# Altium schematic sheet Connections.SchDoc
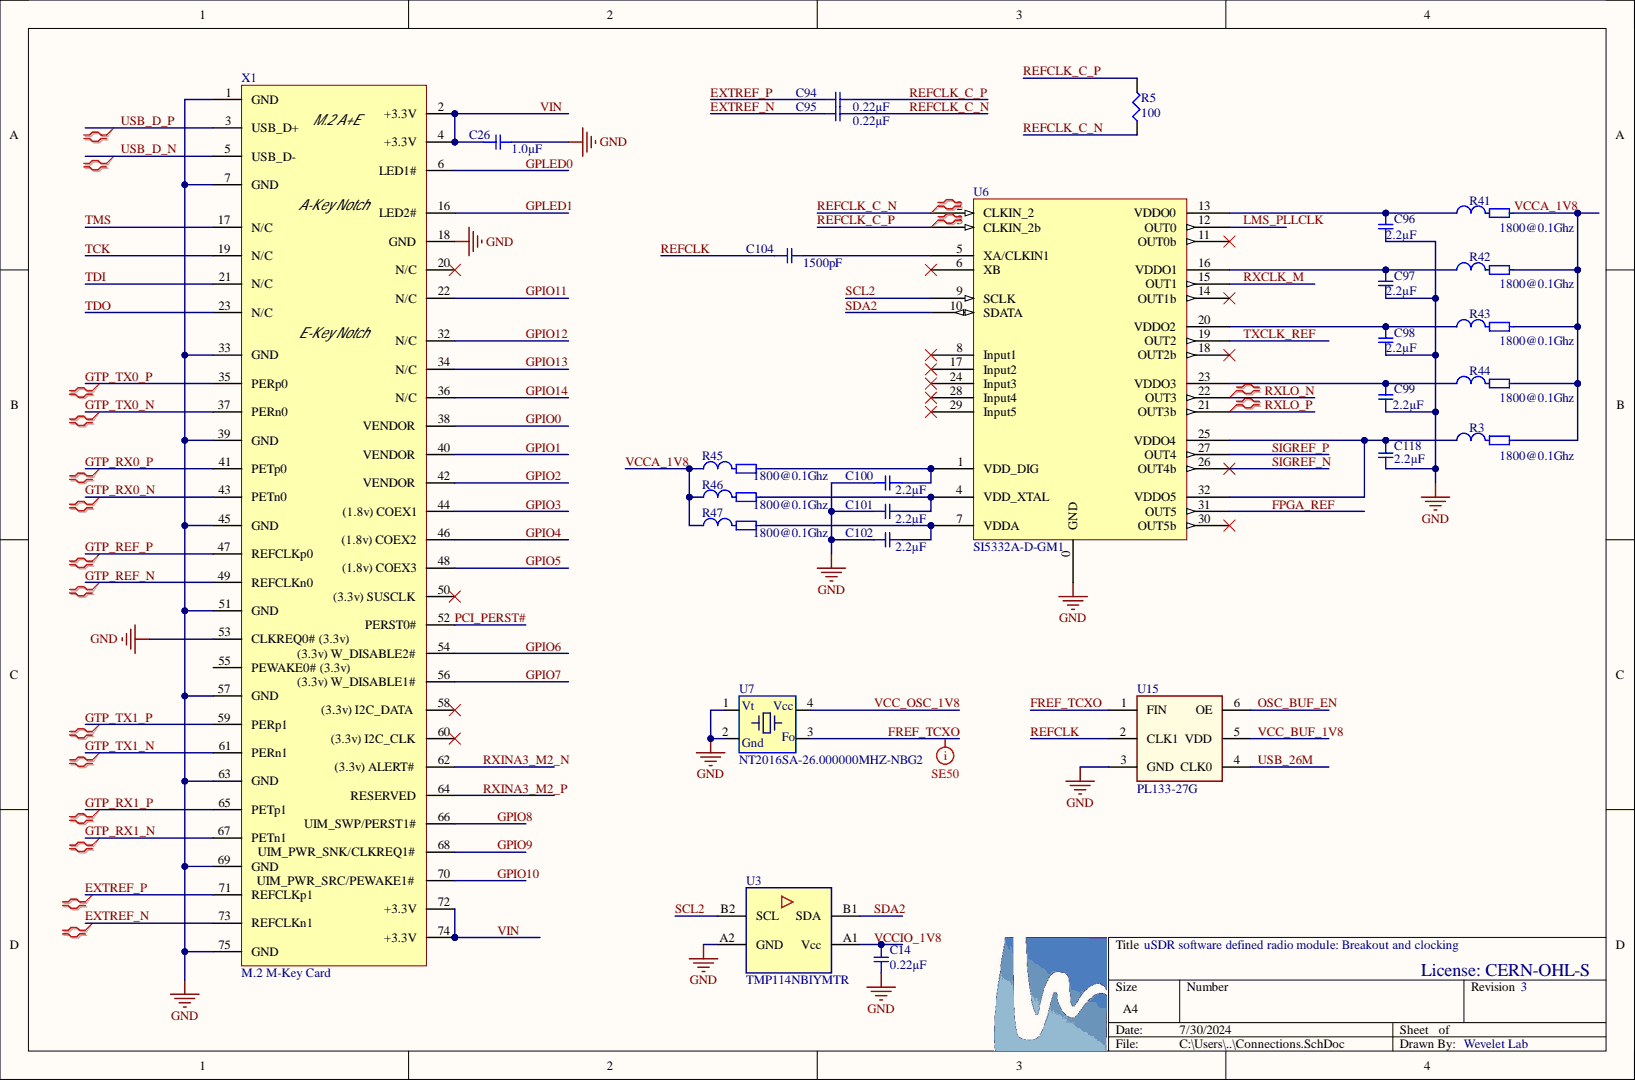
[diagram p0: Connections.SchDoc]

## Parts
C14  value 0.22µF  [SMD-0201C]  (hidden comment: GRM033C80J224ME90D)
C26  value 1.0µF  [SMD-0201C]  (hidden comment: GRM033D70J105ME01D)
C94  value 0.22µF  [SMD-0201C]  (hidden comment: GRM033C80J224ME90D)
C95  value 0.22µF  [SMD-0201C]  (hidden comment: GRM033C80J224ME90D)
C96  value 2.2µF  [SMD-0201C]  (hidden comment: GRM033C80G225ME01D)
C97  value 2.2µF  [SMD-0201C]  (hidden comment: GRM033C80G225ME01D)
C98  value 2.2µF  [SMD-0201C]  (hidden comment: GRM033C80G225ME01D)
C99  value 2.2µF  [SMD-0201C]  (hidden comment: GRM033C80G225ME01D)
C100  value 2.2µF  [SMD-0201C]  (hidden comment: GRM033C80G225ME01D)
C101  value 2.2µF  [SMD-0201C]  (hidden comment: GRM033C80G225ME01D)
C102  value 2.2µF  [SMD-0201C]  (hidden comment: GRM033C80G225ME01D)
C104  value 1500pF  [SMD-0201C]  (hidden comment: GRM033R71E152KA01D)
C118  value 2.2µF  [SMD-0201C]  (hidden comment: GRM033C80G225ME01D)
R3  value 1800@0.1Ghz  [SMD-0201-RES]  (hidden comment: BLM03HD182FN1)
R5  100  [SMD-0201-RES]
R41  value 1800@0.1Ghz  [SMD-0201-RES]  (hidden comment: BLM03HD182FN1)
R42  value 1800@0.1Ghz  [SMD-0201-RES]  (hidden comment: BLM03HD182FN1)
R43  value 1800@0.1Ghz  [SMD-0201-RES]  (hidden comment: BLM03HD182FN1)
R44  value 1800@0.1Ghz  [SMD-0201-RES]  (hidden comment: BLM03HD182FN1)
R45  value 1800@0.1Ghz  [SMD-0201-RES]  (hidden comment: BLM03HD182FN1)
R46  value 1800@0.1Ghz  [SMD-0201-RES]  (hidden comment: BLM03HD182FN1)
R47  value 1800@0.1Ghz  [SMD-0201-RES]  (hidden comment: BLM03HD182FN1)
U3  TMP114NBIYMTR  [BGA WCSP4]
U6  SI5332A-D-GM1  [QFN-32(5X5/0.5)SI]
U7  NT2016SA-26.000000MHZ-NBG2  [TCXO1612_2016_COMBINED]
U15  PL133-27G  [TDFN-6 -2013]
X1  M.2 M-Key Card  [Conn Edge M.2 A/E Key]  (no BOM)

## Nets
GND: C14.2 C26.2 C96.1 C97.1 C98.1 C99.1 C100.2 C101.2 C102.2 C118.2 U3.A2(GND) U6.0(GND) U7.1(Vt) U7.2 U15.3(GND) X1.1(GND) X1.7(GND) X1.18(GND) X1.33(GND) X1.39(GND) X1.45(GND) X1.51(GND) X1.53(CLKREQ0#_(3.3v)) X1.57(GND) X1.63(GND) X1.69(GND) X1.75(GND)
EXTREF_N: C95.2 X1.73(REFCLKn1)
EXTREF_P: C94.2 X1.71(REFCLKp1)
FPGA_REF: U6.31(OUT5)
FREF_TCXO: U7.3(Fo) U15.1(FIN)
GPIO0: X1.38(VENDOR)
GPIO1: X1.40(VENDOR)
GPIO2: X1.42(VENDOR)
GPIO3: X1.44((1.8v)_COEX1)
GPIO4: X1.46((1.8v)_COEX2)
GPIO5: X1.48((1.8v)_COEX3)
GPIO6: X1.54((3.3v)_W_DISABLE2#)
GPIO7: X1.56((3.3v)_W_DISABLE1#)
GPIO8: X1.66(UIM_SWP/PERST1#)
GPIO9: X1.68(UIM_PWR_SNK/CLKREQ1#)
GPIO10: X1.70(UIM_PWR_SRC/PEWAKE1#)
GPIO11: X1.22(N/C)
GPIO12: X1.32(N/C)
GPIO13: X1.34(N/C)
GPIO14: X1.36(N/C)
GPLED0: X1.6(LED1#)
GPLED1: X1.16(LED2#)
GTP_REF_N: X1.49(REFCLKn0)
GTP_REF_P: X1.47(REFCLKp0)
GTP_RX0_N: X1.43(PETn0)
GTP_RX0_P: X1.41(PETp0)
GTP_RX1_N: X1.67(PETn1)
GTP_RX1_P: X1.65(PETp1)
GTP_TX0_N: X1.37(PERn0)
GTP_TX0_P: X1.35(PERp0)
GTP_TX1_N: X1.61(PERn1)
GTP_TX1_P: X1.59(PERp1)
LMS_PLLCLK: U6.12(OUT0)
OSC_BUF_EN: U15.6(OE)
PCI_PERST#: X1.52(PERST0#)
REFCLK: C104.1 U15.2(CLK1)
REFCLK_C_N: C95.1 R5.1 U6.2(CLKIN_2)
REFCLK_C_P: C94.1 R5.2 U6.3(CLKIN_2b)
RXCLK_M: U6.15(OUT1)
RXINA3_M2_N: X1.62((3.3v)_ALERT#)
RXINA3_M2_P: X1.64(RESERVED)
RXLO_N: U6.22(OUT3)
RXLO_P: U6.21(OUT3b)
SCL2: U3.B2(SCL) U6.9(SCLK)
SDA2: U3.B1(SDA) U6.10(SDATA)
SIGREF_N: U6.26(OUT4b)
SIGREF_P: U6.27(OUT4)
TCK: X1.19(N/C)
TDI: X1.21(N/C)
TDO: X1.23(N/C)
TMS: X1.17(N/C)
TXCLK_REF: U6.19(OUT2)
USB_26M: U15.4(CLK0)
USB_D_N: X1.5(USB_D-)
USB_D_P: X1.3(USB_D+)
VCC_BUF_1V8: U15.5(VDD)
VCC_OSC_1V8: U7.4(Vcc)
VCCA_1V8: R3.2 R41.2 R42.2 R43.2 R44.2 R45.1 R46.1 R47.1
VCCIO_1V8: C14.1 U3.A1(Vcc)
VIN: C26.1 X1.2(+3.3V) X1.4(+3.3V) X1.72(+3.3V) X1.74(+3.3V)
NetC96_2: C96.2 R41.1 U6.13(VDDO0)
NetC97_2: C97.2 R42.1 U6.16(VDDO1)
NetC98_2: C98.2 R43.1 U6.20(VDDO2)
NetC99_2: C99.2 R44.1 U6.23(VDDO3)
NetC100_1: C100.1 R45.2 U6.1(VDD_DIG)
NetC101_1: C101.1 R46.2 U6.4(VDD_XTAL)
NetC102_1: C102.1 R47.2 U6.7(VDDA)
NetC104_2: C104.2 U6.5(XA/CLKIN1)
NetC118_1: C118.1 R3.1 U6.25(VDDO4) U6.32(VDDO5)

Not connected: U6 6(XB) 8(Input1) 11(OUT0b) 14(OUT1b) 17(Input2) 18(OUT2b) 24(Input3) 28(Input4) 29(Input5) 30(OUT5b); X1 20(N/C) 50((3.3v)_SUSCLK) 55(PEWAKE0#_(3.3v)) 58((3.3v)_I2C_DATA) 60((3.3v)_I2C_CLK)

## Library data of the parts
C14 C94 C95 = GRM033C80J224ME90D: CAP CER 0.22UF 6.3V X6S 0201; Manufacturer: Murata Electronics North America; @ qty: 0; Minimum Quantity: 1; Tolerance: ±20%; Unit Price (USD): 0.1; Applications: General Purpose; Component Kind: Standard; Datasheets: http://www.murata.com/~/media/webrenewal/support/library/catalog/products/capacitor/mlcc/c02e.pdf; Digi-Key Part Number: 490-9982-1-ND; Factory Stock: 0; Failure Rate: Surface Mount, MLCC; Features: -; Height - Seated (Max): 0.013" (0.33mm); Image: //media.digikey.com/Renders/Murata%20Renders/ZRB-SERIES-0402.jpg; Lead Spacing: -; Mounting Type: 0201 (0603 Metric); Operating Temperature: -55°C ~ 105°C; Package / Case: 0.024" L x 0.012" W (0.60mm x 0.30mm); Packaging: Cut Tape (CT); Part Number: GRM033C80J224ME90D; Part Status: Active; Quantity Available: 381529; Ratings: -; Series: GRM; Size / Dimension: -; Temperature Coefficient: X6S; Thickness (Max): -; Voltage: 6.3V; Library Name: USDR.IntLib
C26 = GRM033D70J105ME01D: CAP CER 1.0UF 6.3V X7T 0201; Manufacturer: Murata Electronics North America; @ qty: 0; Minimum Quantity: 1; Tolerance: ±20%; Unit Price (USD): 0.54; Applications: General Purpose; Component Kind: Standard; Datasheets: http://psearch.en.murata.com/capacitor/product/GRM035R60J475ME15%23.pdf; Digi-Key Part Number: 490-GRM033D70J105ME01DTR-ND; Factory Stock: 0; Failure Rate: Surface Mount, MLCC; Features: Derating Recommended; Height - Seated (Max): 0.022" (0.55mm); Image: //media.digikey.com/Renders/Murata%20Renders/ZRB-SERIES-0402.jpg; Lead Spacing: -; Mounting Type: 0201 (0603 Metric); Operating Temperature: -55°C ~125°C; Package / Case: 0.024" L x 0.012" W (0.60mm x 0.30mm); Packaging: Cut Tape (CT); Part Number: GRM033D70J105ME01D; Part Status: Active; Quantity Available: 67188; Ratings: -; Series: GRM; Size / Dimension: -; Temperature Coefficient: X7T; Thickness (Max): -; Voltage: 6.3V; Library Name: USDR.IntLib
C96-C102 C118 = GRM033C80G225ME01D: CAP CER 2.2UF 4V X6S 0201; Manufacturer: Murata Electronics North America; @ qty: 0; Minimum Quantity: 1; Tolerance: ±20%; Unit Price (USD): 0.54; Voltage: 6.3V; Applications: General Purpose; Component Kind: Standard; Datasheets: http://psearch.en.murata.com/capacitor/product/GRM035R60J475ME15%23.pdf; Digi-Key Part Number: 490-GRM033C80G225ME01DTR-ND; Factory Stock: 0; Failure Rate: Surface Mount, MLCC; Features: Derating Recommended; Height - Seated (Max): 0.022" (0.55mm); Image: //media.digikey.com/Renders/Murata%20Renders/ZRB-SERIES-0402.jpg; Lead Spacing: -; Mounting Type: 0201 (0603 Metric); Operating Temperature: -55°C ~105°C; Package / Case: 0.024" L x 0.012" W (0.60mm x 0.30mm); Packaging: Cut Tape (CT); Part Number: GRM033C80G225ME01D; Part Status: Active; Quantity Available: 67188; Ratings: -; Series: GRM; Size / Dimension: -; Temperature Coefficient: X6S; Thickness (Max): -; Library Name: USDR.IntLib
C104 = GRM033R71E152KA01D: CAP CER 1500PF 25V X7R 0201; Manufacturer: Murata Electronics North America; @ qty: 0; Minimum Quantity: 1; Tolerance: ±10%; Unit Price (USD): 0.1; Applications: General Purpose; Component Kind: Standard; Datasheets: http://www.murata.com/~/media/webrenewal/support/library/catalog/products/capacitor/mlcc/c02e.pdf; Digi-Key Part Number: 490-3185-1-ND; Factory Stock: 0; Failure Rate: Surface Mount, MLCC; Features: -; Height - Seated (Max): 0.013" (0.33mm); Image: //media.digikey.com/Renders/Murata%20Renders/ZRB-SERIES-0402.jpg; Lead Spacing: -; Mounting Type: 0201 (0603 Metric); Operating Temperature: -55°C ~ 125°C; Package / Case: 0.024" L x 0.012" W (0.60mm x 0.30mm); Packaging: Cut Tape (CT); Part Number: GRM033R71E152KA01D; Part Status: Active; Quantity Available: 60053; Ratings: -; Series: GRM; Size / Dimension: -; Temperature Coefficient: X7R; Thickness (Max): -; Voltage: 25V; Library Name: USDR.IntLib
R3 R41-R47 = BLM03HD182FN1: BEAD 3.8Ohm/100mA 1800@0.1Ghz/3000@1.0Ghz; Manufacturer: TDKMurata Electronics North America; Tolerance: ±3%; Component Kind: Standard; Link: https://product.tdk.com/system/files/dam/doc/product/inductor/inductor/smd/catalog/inductor_commercial_high-frequency_mlg0603p_en.pdf; Name: BLM03HD182FN1; Library Name: USDR.IntLib
R5 = ERJ-1GEJ101C: RES SMD 100 OHM 5% 1/20W 0201; Manufacturer: Panasonic Electronic Components; @ qty: 1500; Factory Stock: 0; Minimum Quantity: 1; Power (Watts): 0.05W, 1/20W; Temperature Coefficient: ±200ppm/°C; Unit Price (USD): 0.00787; Component Kind: Standard; Composition: Thick Film; Datasheets: //media.digikey.com/pdf/Data%20Sheets/Panasonic%20Resistors%20Thermistors%20PDFs/ERJ%205%25.pdf; Digi-Key Part Number: P100AGCT-ND; Failure Rate: -; Features: -; Height - Seated (Max): 0.010" (0.26mm); Image: //media.digikey.com/Renders/Panasonic%20Renders/ERJ-0201%20Pkg.jpg; Number of Terminations: 2; Operating Temperature: -55°C ~ 125°C; Package / Case: 0201 (0603 Metric); Packaging: Cut Tape (CT); Part Number: ERJ-1GEJ101C; Part Status: Active; Quantity Available: 115724; Series: ERJ; Size / Dimension: 0.024" L x 0.012" W (0.60mm x 0.30mm); Supplier Device Package: 0201; Tolerance: ±5%; Value: 100; Library Name: USDR.IntLib
U3 = TMP114NBIYMTR: IC Thermosensor; Manufacturer: TI; Misc: IC temperature sensor I2C, DSBGA-6; Component Kind: Standard; Link: https://octopart.com/tmp108aiyffr-texas+instruments-28946339; Name: TMP114NBIYMTR; Library Name: USDR.IntLib
U6 = SI5332A-D-GM1: Clock Generator 10MHz to 250MHz-IN 333.33MHz-OUT; Component Kind: Standard; ComponentLink1Description: Link; ComponentLink1URL: https://octopart.com/search?q=%27SI5332A-D-GM1&currency=USD&specs=0&in_stock_only=1; Library Name: USDR.IntLib
U7 = NT2016SA-26.000000MHZ-NBG2: TCXO 26.000Mhz Clipped Sine Wave 1.8V 500ppb; Manufacturer: NDK; Component Kind: Standard; Misc: TCXO; Name: NT2016SA-26.000000MHZ-NBG2; Library Name: USDR.IntLib
U15 = PL133-27: IC 2-channel multiplexer\demultiplexer; Manufacturer: Nexperia; Component Kind: Standard; ComponentLink1Description: Link; ComponentLink1URL: https://octopart.com/search?q=TPS7A3501DRV&currency=USD&specs=0&in_stock_only=1; Name: PL133-27G; PDF: https://assets.nexperia.com/documents/data-sheet/74LVC1G3157.pdf; Library Name: USDR.IntLib
X1 = M2TRX M.2 A/E-Key Edge Conn: M.2 A/E-Key Card Golden Finger Edge Connector; System: Connector; Library Name: USDR.IntLib
